# Altium schematic sheet Nixie.SchDoc
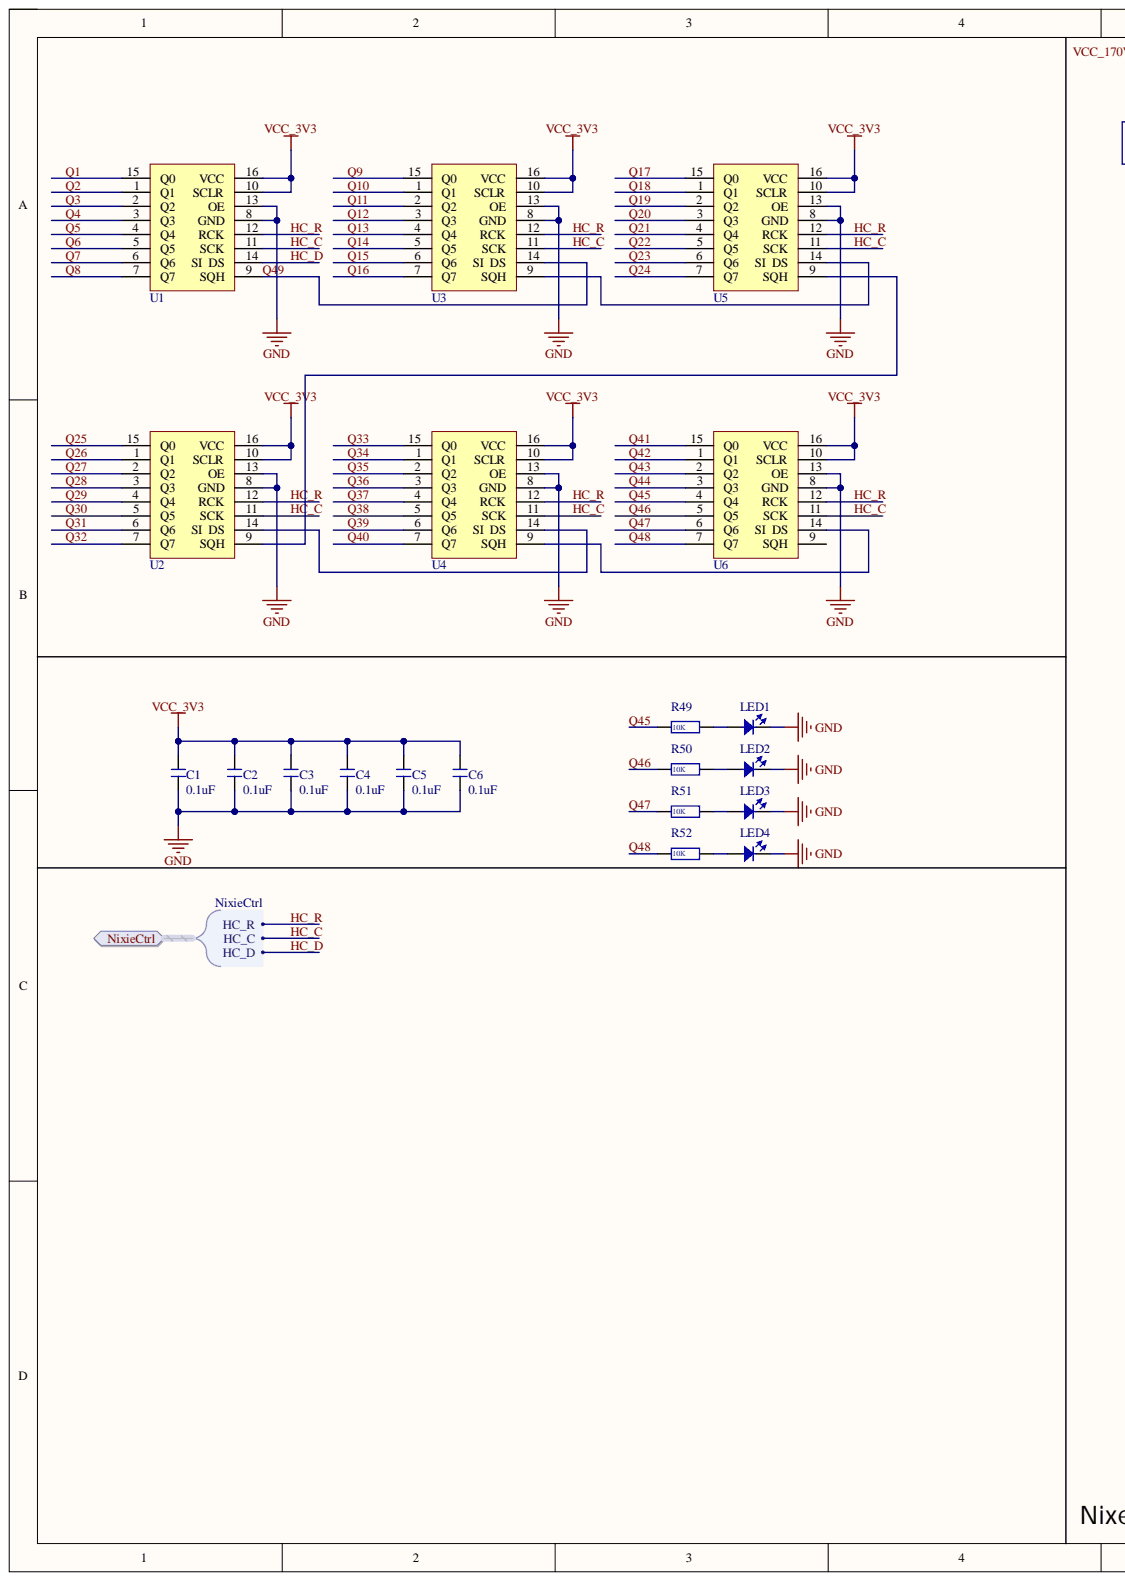
[diagram p0: Nixie.SchDoc, left]
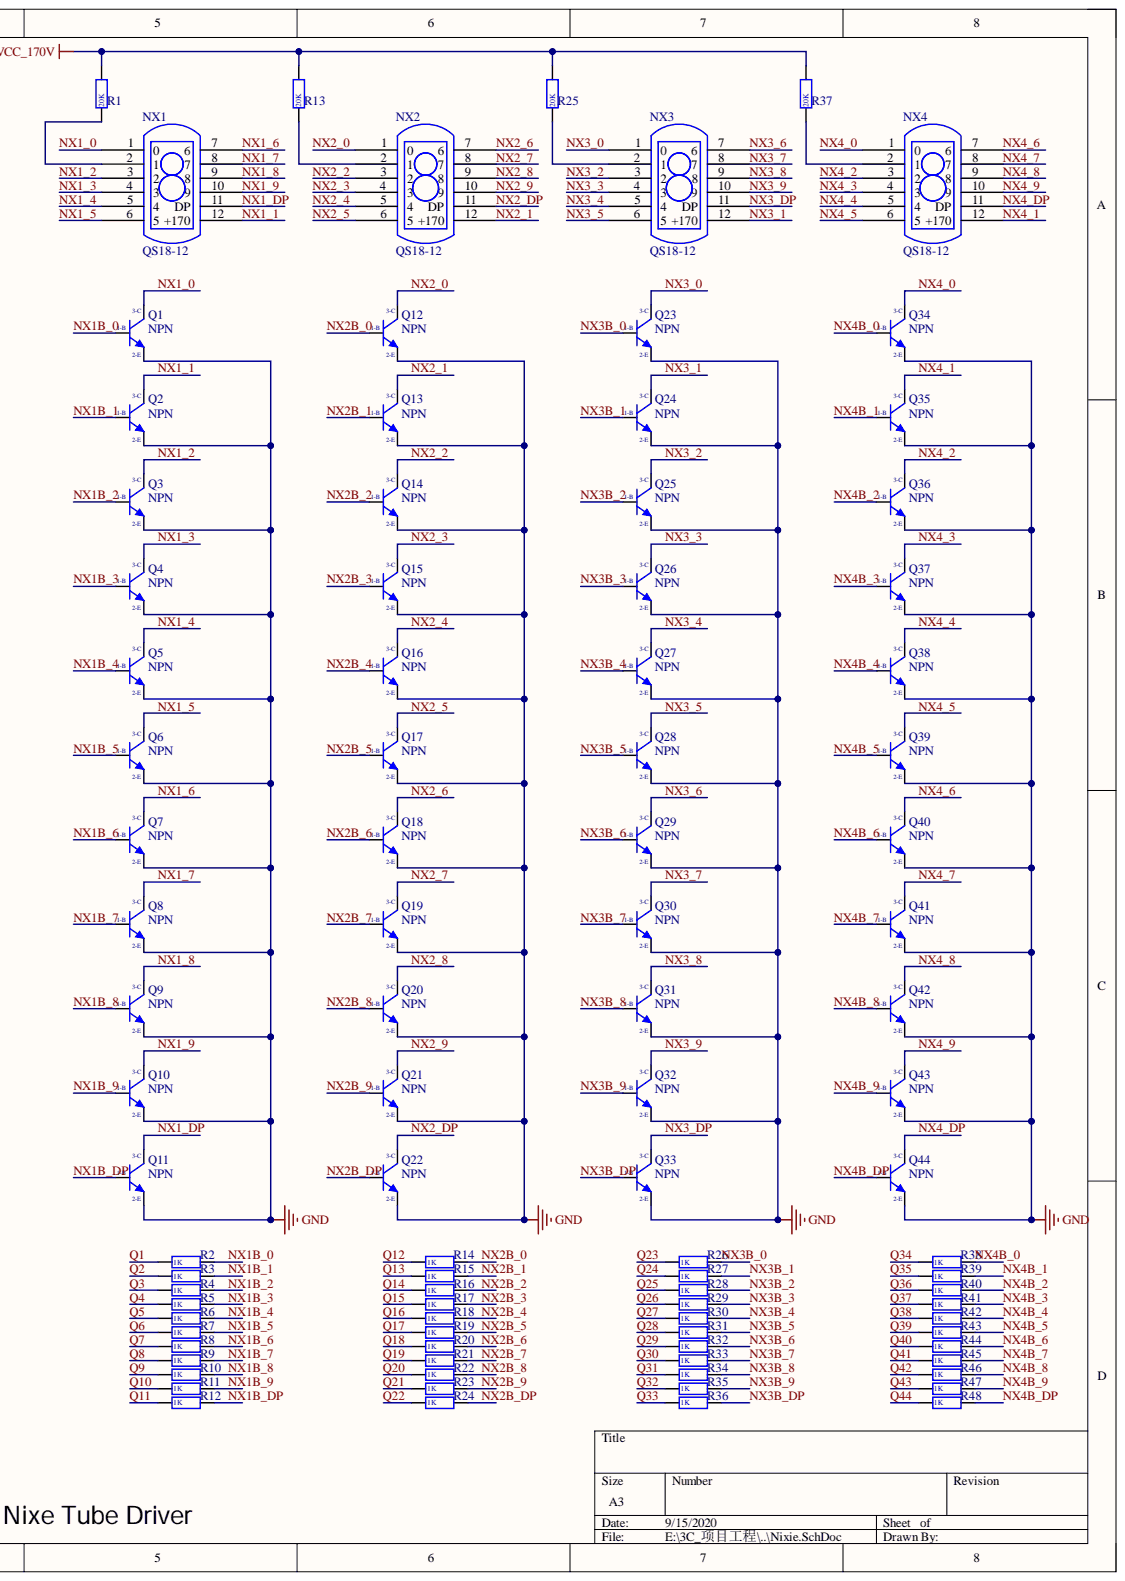
[diagram p1: Nixie.SchDoc, right]

## Parts
C1  0.1uF  [C 0805]
C2  0.1uF  [C 0805]
C3  0.1uF  [C 0805]
C4  0.1uF  [C 0805]
C5  0.1uF  [C 0805]
C6  0.1uF  [C 0805]
LED1  LED  [0805D]
LED2  LED  [0805D]
LED3  LED  [0805D]
LED4  LED  [0805D]
NX1  QS18-12  [PCBComponent_1]
NX2  QS18-12  [PCBComponent_1]
NX3  QS18-12  [PCBComponent_1]
NX4  QS18-12  [PCBComponent_1]
Q1  NPN  [SOT-23]
Q2  NPN  [SOT-23]
Q3  NPN  [SOT-23]
Q4  NPN  [SOT-23]
Q5  NPN  [SOT-23]
Q6  NPN  [SOT-23]
Q7  NPN  [SOT-23]
Q8  NPN  [SOT-23]
Q9  NPN  [SOT-23]
Q10  NPN  [SOT-23]
Q11  NPN  [SOT-23]
Q12  NPN  [SOT-23]
Q13  NPN  [SOT-23]
Q14  NPN  [SOT-23]
Q15  NPN  [SOT-23]
Q16  NPN  [SOT-23]
Q17  NPN  [SOT-23]
Q18  NPN  [SOT-23]
Q19  NPN  [SOT-23]
Q20  NPN  [SOT-23]
Q21  NPN  [SOT-23]
Q22  NPN  [SOT-23]
Q23  NPN  [SOT-23]
Q24  NPN  [SOT-23]
Q25  NPN  [SOT-23]
Q26  NPN  [SOT-23]
Q27  NPN  [SOT-23]
Q28  NPN  [SOT-23]
Q29  NPN  [SOT-23]
Q30  NPN  [SOT-23]
Q31  NPN  [SOT-23]
Q32  NPN  [SOT-23]
Q33  NPN  [SOT-23]
Q34  NPN  [SOT-23]
Q35  NPN  [SOT-23]
Q36  NPN  [SOT-23]
Q37  NPN  [SOT-23]
Q38  NPN  [SOT-23]
Q39  NPN  [SOT-23]
Q40  NPN  [SOT-23]
Q41  NPN  [SOT-23]
Q42  NPN  [SOT-23]
Q43  NPN  [SOT-23]
Q44  NPN  [SOT-23]
R1  R  value 20K  [R 0603]
R2  R  value 1K  [R 0603]
R3  R  value 1K  [R 0603]
R4  R  value 1K  [R 0603]
R5  R  value 1K  [R 0603]
R6  R  value 1K  [R 0603]
R7  R  value 1K  [R 0603]
R8  R  value 1K  [R 0603]
R9  R  value 1K  [R 0603]
R10  R  value 1K  [R 0603]
R11  R  value 1K  [R 0603]
R12  R  value 1K  [R 0603]
R13  R  value 20K  [R 0603]
R14  R  value 1K  [R 0603]
R15  R  value 1K  [R 0603]
R16  R  value 1K  [R 0603]
R17  R  value 1K  [R 0603]
R18  R  value 1K  [R 0603]
R19  R  value 1K  [R 0603]
R20  R  value 1K  [R 0603]
R21  R  value 1K  [R 0603]
R22  R  value 1K  [R 0603]
R23  R  value 1K  [R 0603]
R24  R  value 1K  [R 0603]
R25  R  value 20K  [R 0603]
R26  R  value 1K  [R 0603]
R27  R  value 1K  [R 0603]
R28  R  value 1K  [R 0603]
R29  R  value 1K  [R 0603]
R30  R  value 1K  [R 0603]
R31  R  value 1K  [R 0603]
R32  R  value 1K  [R 0603]
R33  R  value 1K  [R 0603]
R34  R  value 1K  [R 0603]
R35  R  value 1K  [R 0603]
R36  R  value 1K  [R 0603]
R37  R  value 20K  [R 0603]
R38  R  value 1K  [R 0603]
R39  R  value 1K  [R 0603]
R40  R  value 1K  [R 0603]
R41  R  value 1K  [R 0603]
R42  R  value 1K  [R 0603]
R43  R  value 1K  [R 0603]
R44  R  value 1K  [R 0603]
R45  R  value 1K  [R 0603]
R46  R  value 1K  [R 0603]
R47  R  value 1K  [R 0603]
R48  R  value 1K  [R 0603]
R49  R  value 10K  [R 0603]
R50  R  value 10K  [R 0603]
R51  R  value 10K  [R 0603]
R52  R  value 10K  [R 0603]
U1  74HC595  [SOP-16]  (value not drawn)
U2  74HC595  [SOP-16]  (value not drawn)
U3  74HC595  [SOP-16]  (value not drawn)
U4  74HC595  [SOP-16]  (value not drawn)
U5  74HC595  [SOP-16]  (value not drawn)
U6  74HC595  [SOP-16]  (value not drawn)

## Ports
NixieCtrl, NixieCtrl.HC_C, NixieCtrl.HC_D, NixieCtrl.HC_R

## Nets
GND: C1.2 C2.2 C3.2 C4.2 C5.2 C6.2 LED1.2 LED2.2 LED3.2 LED4.2 Q1.2 Q2.2 Q3.2 Q4.2 Q5.2 Q6.2 Q7.2 Q8.2 Q9.2 Q10.2 Q11.2 Q12.2 Q13.2 Q14.2 Q15.2 Q16.2 Q17.2 Q18.2 Q19.2 Q20.2 Q21.2 Q22.2 Q23.2 Q24.2 Q25.2 Q26.2 Q27.2 Q28.2 Q29.2 Q30.2 Q31.2 Q32.2 Q33.2 Q34.2 Q35.2 Q36.2 Q37.2 Q38.2 Q39.2 Q40.2 Q41.2 Q42.2 Q43.2 Q44.2 U1.8(GND) U1.13(OE) U2.8(GND) U2.13(OE) U3.8(GND) U3.13(OE) U4.8(GND) U4.13(OE) U5.8(GND) U5.13(OE) U6.8(GND) U6.13(OE)
VCC_3V3: C1.1 C2.1 C3.1 C4.1 C5.1 C6.1 U1.10(SCLR) U1.16(VCC) U2.10(SCLR) U2.16(VCC) U3.10(SCLR) U3.16(VCC) U4.10(SCLR) U4.16(VCC) U5.10(SCLR) U5.16(VCC) U6.10(SCLR) U6.16(VCC)
VCC_170V: R1.2 R13.2 R25.2 R37.2
HC_C: U1.11(SCK) U2.11(SCK) U3.11(SCK) U4.11(SCK) U5.11(SCK) U6.11(SCK) ; port NixieCtrl
HC_D: U1.14(DS) ; port NixieCtrl
HC_R: U1.12(RCK) U2.12(RCK) U3.12(RCK) U4.12(RCK) U5.12(RCK) U6.12(RCK) ; port NixieCtrl
NX1_0: NX1.1 Q1.3
NX1_1: NX1.12(+170) Q2.3
NX1_2: NX1.3 Q3.3
NX1_3: NX1.4 Q4.3
NX1_4: NX1.5 Q5.3
NX1_5: NX1.6 Q6.3
NX1_6: NX1.7 Q7.3
NX1_7: NX1.8 Q8.3
NX1_8: NX1.9 Q9.3
NX1_9: NX1.10 Q10.3
NX1_DP: NX1.11(DP) Q11.3
NX1B_0: Q1.1 R2.2
NX1B_1: Q2.1 R3.2
NX1B_2: Q3.1 R4.2
NX1B_3: Q4.1 R5.2
NX1B_4: Q5.1 R6.2
NX1B_5: Q6.1 R7.2
NX1B_6: Q7.1 R8.2
NX1B_7: Q8.1 R9.2
NX1B_8: Q9.1 R10.2
NX1B_9: Q10.1 R11.2
NX1B_DP: Q11.1 R12.2
NX2_0: NX2.1 Q12.3
NX2_1: NX2.12(+170) Q13.3
NX2_2: NX2.3 Q14.3
NX2_3: NX2.4 Q15.3
NX2_4: NX2.5 Q16.3
NX2_5: NX2.6 Q17.3
NX2_6: NX2.7 Q18.3
NX2_7: NX2.8 Q19.3
NX2_8: NX2.9 Q20.3
NX2_9: NX2.10 Q21.3
NX2_DP: NX2.11(DP) Q22.3
NX2B_0: Q12.1 R14.2
NX2B_1: Q13.1 R15.2
NX2B_2: Q14.1 R16.2
NX2B_3: Q15.1 R17.2
NX2B_4: Q16.1 R18.2
NX2B_5: Q17.1 R19.2
NX2B_6: Q18.1 R20.2
NX2B_7: Q19.1 R21.2
NX2B_8: Q20.1 R22.2
NX2B_9: Q21.1 R23.2
NX2B_DP: Q22.1 R24.2
NX3_0: NX3.1 Q23.3
NX3_1: NX3.12(+170) Q24.3
NX3_2: NX3.3 Q25.3
NX3_3: NX3.4 Q26.3
NX3_4: NX3.5 Q27.3
NX3_5: NX3.6 Q28.3
NX3_6: NX3.7 Q29.3
NX3_7: NX3.8 Q30.3
NX3_8: NX3.9 Q31.3
NX3_9: NX3.10 Q32.3
NX3_DP: NX3.11(DP) Q33.3
NX3B_0: Q23.1 R26.2
NX3B_1: Q24.1 R27.2
NX3B_2: Q25.1 R28.2
NX3B_3: Q26.1 R29.2
NX3B_4: Q27.1 R30.2
NX3B_5: Q28.1 R31.2
NX3B_6: Q29.1 R32.2
NX3B_7: Q30.1 R33.2
NX3B_8: Q31.1 R34.2
NX3B_9: Q32.1 R35.2
NX3B_DP: Q33.1 R36.2
NX4_0: NX4.1 Q34.3
NX4_1: NX4.12(+170) Q35.3
NX4_2: NX4.3 Q36.3
NX4_3: NX4.4 Q37.3
NX4_4: NX4.5 Q38.3
NX4_5: NX4.6 Q39.3
NX4_6: NX4.7 Q40.3
NX4_7: NX4.8 Q41.3
NX4_8: NX4.9 Q42.3
NX4_9: NX4.10 Q43.3
NX4_DP: NX4.11(DP) Q44.3
NX4B_0: Q34.1 R38.2
NX4B_1: Q35.1 R39.2
NX4B_2: Q36.1 R40.2
NX4B_3: Q37.1 R41.2
NX4B_4: Q38.1 R42.2
NX4B_5: Q39.1 R43.2
NX4B_6: Q40.1 R44.2
NX4B_7: Q41.1 R45.2
NX4B_8: Q42.1 R46.2
NX4B_9: Q43.1 R47.2
NX4B_DP: Q44.1 R48.2
Q1: R2.1 U1.15(Q0)
Q2: R3.1 U1.1(Q1)
Q3: R4.1 U1.2(Q2)
Q4: R5.1 U1.3(Q3)
Q5: R6.1 U1.4(Q4)
Q6: R7.1 U1.5(Q5)
Q7: R8.1 U1.6(Q6)
Q8: R9.1 U1.7(Q7)
Q9: R10.1 U3.15(Q0)
Q10: R11.1 U3.1(Q1)
Q11: R12.1 U3.2(Q2)
Q12: R14.1 U3.3(Q3)
Q13: R15.1 U3.4(Q4)
Q14: R16.1 U3.5(Q5)
Q15: R17.1 U3.6(Q6)
Q16: R18.1 U3.7(Q7)
Q17: R19.1 U5.15(Q0)
Q18: R20.1 U5.1(Q1)
Q19: R21.1 U5.2(Q2)
Q20: R22.1 U5.3(Q3)
Q21: R23.1 U5.4(Q4)
Q22: R24.1 U5.5(Q5)
Q23: R26.1 U5.6(Q6)
Q24: R27.1 U5.7(Q7)
Q25: R28.1 U2.15(Q0)
Q26: R29.1 U2.1(Q1)
Q27: R30.1 U2.2(Q2)
Q28: R31.1 U2.3(Q3)
Q29: R32.1 U2.4(Q4)
Q30: R33.1 U2.5(Q5)
Q31: R34.1 U2.6(Q6)
Q32: R35.1 U2.7(Q7)
Q33: R36.1 U4.15(Q0)
Q34: R38.1 U4.1(Q1)
Q35: R39.1 U4.2(Q2)
Q36: R40.1 U4.3(Q3)
Q37: R41.1 U4.4(Q4)
Q38: R42.1 U4.5(Q5)
Q39: R43.1 U4.6(Q6)
Q40: R44.1 U4.7(Q7)
Q41: R45.1 U6.15(Q0)
Q42: R46.1 U6.1(Q1)
Q43: R47.1 U6.2(Q2)
Q44: R48.1 U6.3(Q3)
Q45: R49.1 U6.4(Q4)
Q46: R50.1 U6.5(Q5)
Q47: R51.1 U6.6(Q6)
Q48: R52.1 U6.7(Q7)
Q49: U1.9(SQH) U3.14(DS)
NetLED1_1: LED1.1 R49.2
NetLED2_1: LED2.1 R50.2
NetLED3_1: LED3.1 R51.2
NetLED4_1: LED4.1 R52.2
NetNX1_2: NX1.2 R1.1
NetNX2_2: NX2.2 R13.1
NetNX3_2: NX3.2 R25.1
NetNX4_2: NX4.2 R37.1
NetU2_9: U2.9(SQH) U5.9(SQH)
NetU2_14: U2.14(DS) U4.14(DS)
NetU3_9: U3.9(SQH) U5.14(DS)
NetU4_9: U4.9(SQH) U6.14(DS)

Not connected: U6 9(SQH)

## Library data of the parts
C1-C6 = C: 无极性贴片电容; 版权归属: 源创客; BOM名称: 无极性贴片电容; Component Kind: Standard; Library Name: 电阻-电容-电感.SchLib; 学习资源: www.ooocke.com
Q1-Q44 = NPN-S8050-SOT-23: Component Kind: Standard; Library Name: 吴原理图库.SchLib
U1-U6 = 74HC595_1: Component Kind: Standard; Library Name: 吴原理图库.SchLib
LED1-LED4 = LED: Component Kind: Standard; LatestRevisionDate: 08-Jul-2005; LatestRevisionNote: Mech Layer 1 removed.; PackageDescription: LED; 2 Leads; PackageReference: LED-0; Published: 8-Jun-2000; Library Name: 吴原理图库.SchLib; Publisher: Altium Limited
NX1-NX4 = QS18-12: Component Kind: Standard; Library Name: Nixie.SchLib
R1-R52 = R: Component Kind: Standard; Library Name: 吴原理图库.SchLib
